# Altium schematic sheet Sheet1.SchDoc
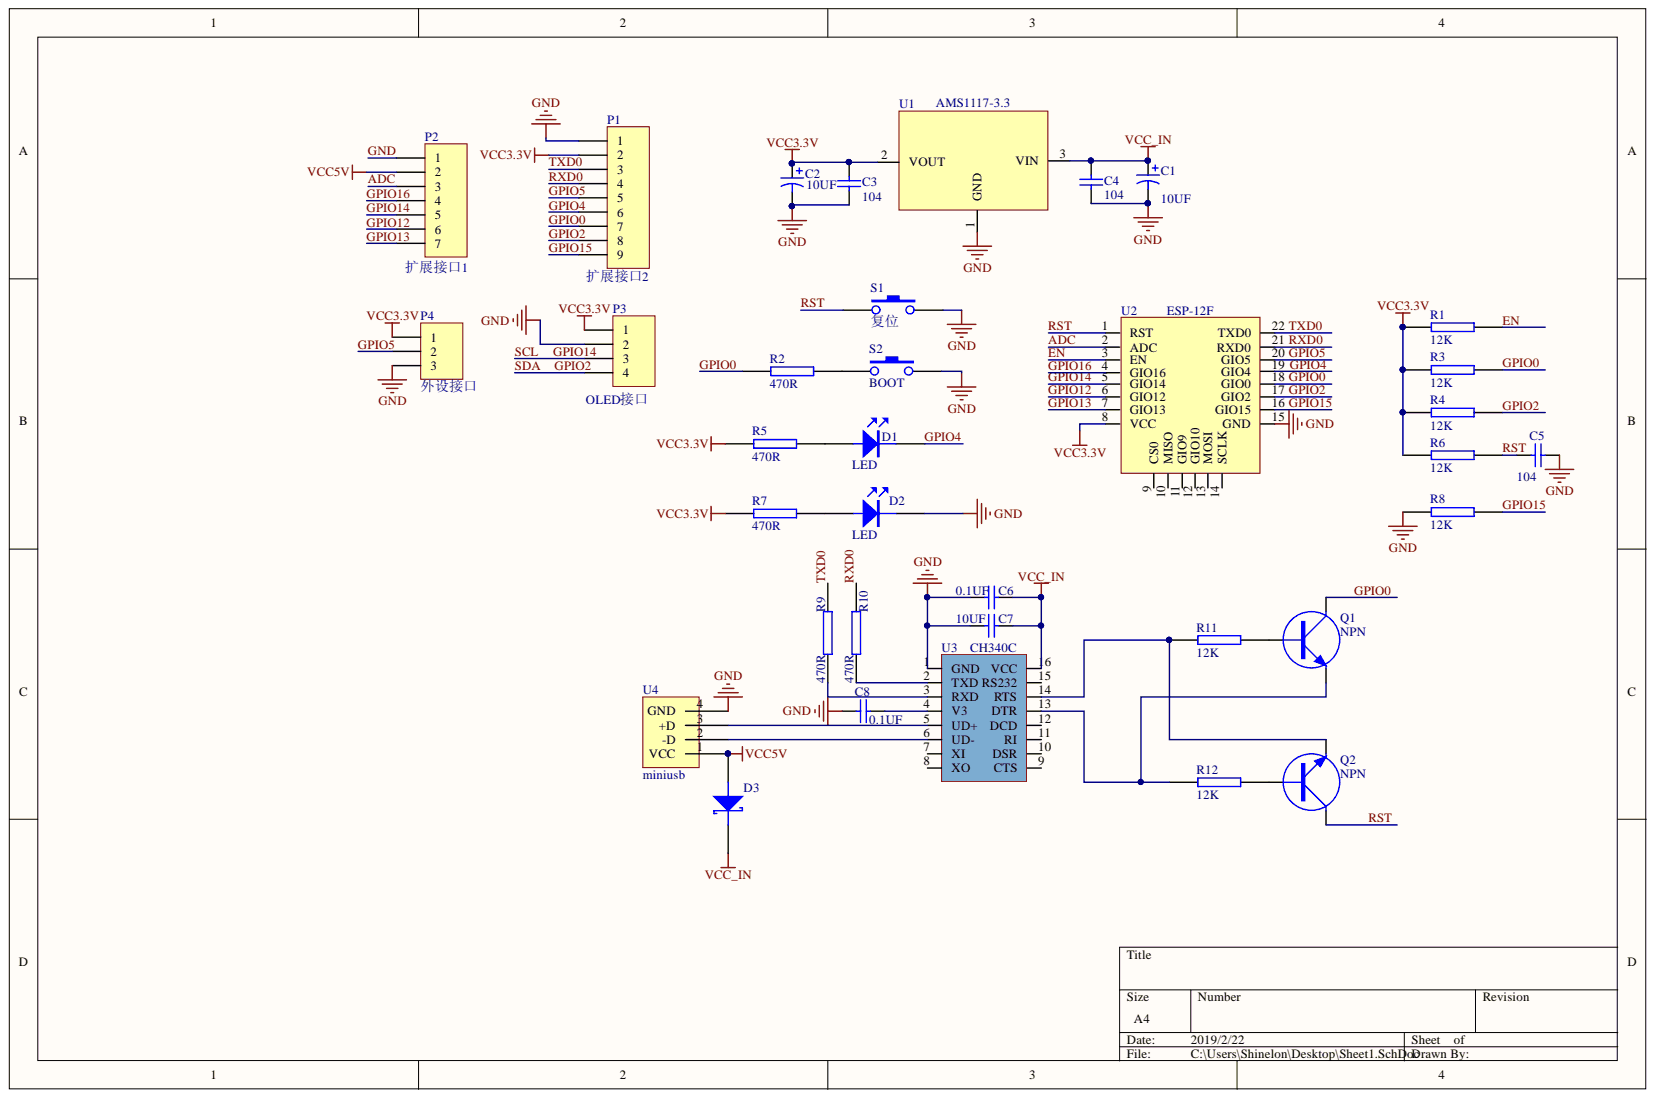
[diagram p0: Sheet1.SchDoc]

## Parts
C1  value 10UF  [3216C]
C2  value 10UF  [3216C]
C3  value 104  [0603C]  (hidden comment: Cap)
C4  value 104  [0603C]  (hidden comment: Cap)
C5  value 104  [0603C]  (hidden comment: Cap)
C6  value 0.1UF  [0603C]  (hidden comment: Cap)
C7  value 10UF  [0805C]  (hidden comment: Cap)
C8  value 0.1UF  [0603C]  (hidden comment: Cap)
D1  LED  [0603D]
D2  LED  [0603D]
D3  (no value)  [SOD523]
P1  扩展接口2  [HDR1X9]
P2  扩展接口1  [HDR1X7]
P3  OLED接口  [HDR1X4]
P4  外设接口  [HDR1X3]
Q1  NPN  [SOT23A]
Q2  NPN  [SOT23A]
R1  12K  [0603m]
R2  470R  [0603m]
R3  12K  [0603m]
R4  12K  [0603m]
R5  470R  [0603m]
R6  12K  [0603m]
R7  470R  [0603m]
R8  12K  [0603m]
R9  470R  [0603m]
R10  470R  [0603m]
R11  12K  [0603m]
R12  12K  [0603m]
S1  复位  [Button_SMD]
S2  BOOT  [Button_SMD]
U1  AMS1117-3.3  [SOT223]
U2  ESP-12F  [ESP-12F]
U3  CH340C  [SO-16_M]
U4  miniusb  [USB1]

## Nets
VCC3.3V: C2.1 C3.2 P1.2 P3.1 P4.1 R1.1 R3.1 R4.1 R5.2 R6.1 R7.2 U1.2 U2.8(VCC)
VCC5V: D3.1 P2.2 U4.1(VCC)
VCC_IN: C1.1 C4.1 C6.2 C7.2 D3.2 U1.3 U3.16(VCC)
ADC: P2.3 U2.2(ADC)
EN: R1.2 U2.3(EN)
GND: C1.2 C2.2 C3.1 C4.2 C5.1 C6.1 C7.1 C8.1 D2.K P1.1 P2.1 P3.2 P4.3 R8.1 S1.2 S2.2 U1.1 U2.15(GND) U3.1(GND) U4.4(GND)
GPIO0: P1.7 Q1.3 R2.2 R3.2 U2.18(GIO0)
GPIO2: P1.8 P3.4 R4.2 U2.17(GIO2)
GPIO4: D1.K P1.6 U2.19(GIO4)
GPIO5: P1.5 P4.2 U2.20(GIO5)
GPIO12: P2.6 U2.6(GIO12)
GPIO13: P2.7 U2.7(GIO13)
GPIO14: P2.5 P3.3 U2.5(GIO14)
GPIO15: P1.9 R8.2 U2.16(GIO15)
GPIO16: P2.4 U2.4(GIO16)
RST: C5.2 Q2.3 R6.2 S1.1 U2.1(RST)
RXD0: P1.4 R10.2 U2.21(RXD0)
TXD0: P1.3 R9.2 U2.22(TXD0)
NetC8_2: C8.2 U3.4(V3)
NetD1_A: D1.A R5.1
NetD2_A: D2.A R7.1
NetQ1_1: Q1.1 R12.1 U3.13(DTR)
NetQ1_2: Q1.2 R11.2
NetQ2_1: Q2.1 R11.1 U3.14(RTS)
NetQ2_2: Q2.2 R12.2
NetR2_1: R2.1 S2.1
NetR9_1: R9.1 U3.3(RXD)
NetR10_1: R10.1 U3.2(TXD)
NetU3_5: U3.5(UD+) U4.3(+D)
NetU3_6: U3.6(UD-) U4.2(-D)

Not connected: U2 9(CS0) 10(MISO) 11(GIO9) 12(GIO10) 13(MOSI) 14(SCLK); U3 7(XI) 8(XO) 9(CTS) 10(DSR) 11(RI) 12(DCD) 15(RS232)

## Library data of the parts
C1 C2 = Cap Pol1: Polarized Capacitor (Radial); Component Kind: Standard; LatestRevisionDate: 17-Jul-2002; LatestRevisionNote: Re-released for DXP Platform.; Library Name: Miscellaneous Devices.IntLib; PackageDescription: Polarized Capacitor (Radial); 2 Leads; PackageReference: RB7.6-15; Published: 8-Jun-2000; Signal Integrity: Cap Pol; Simulation: CAP; Publisher: Altium Limited
C3-C8 = Cap: Capacitor; Component Kind: Standard; LatestRevisionDate: 17-Jul-2002; LatestRevisionNote: Re-released for DXP Platform.; Library Name: Miscellaneous Devices.IntLib; PackageDescription: Radial Cap, Thru-Hole; 2 Leads; 0.3 in Pin Spacing; PackageReference: RAD-0.3; Published: 8-Jun-2000; Signal Integrity: Cap; Simulation: CAP; Publisher: Altium Limited
D3 = DIODE SCHOTTKY: Schottky Diode; Component Kind: Standard; Library Name: Miscellaneous Devices.SCHLIB
P1 = Header 9: Header, 9-Pin; Component Kind: Standard; LatestRevisionDate: 17-Jul-2002; LatestRevisionNote: Re-released for DXP Platform.; Library Name: Miscellaneous Connectors.IntLib; Signal Integrity: Connector; Publisher: Altium Limited
P2 = Header 7: Header, 7-Pin; Component Kind: Standard; LatestRevisionDate: 17-Jul-2002; LatestRevisionNote: Re-released for DXP Platform.; Library Name: Miscellaneous Connectors.IntLib; Signal Integrity: Connector; Publisher: Altium Limited
P3 = Header 4: Header, 4-Pin; Component Kind: Standard; LatestRevisionDate: 17-Jul-2002; LatestRevisionNote: Re-released for DXP Platform.; Library Name: Miscellaneous Connectors.IntLib; Signal Integrity: Connector; Publisher: Altium Limited
P4 = Header 3: Header, 3-Pin; Component Kind: Standard; LatestRevisionDate: 17-Jul-2002; LatestRevisionNote: Re-released for DXP Platform.; Library Name: Miscellaneous Connectors.IntLib; Signal Integrity: Connector; Publisher: Altium Limited
Q1 Q2 = NPN: NPN Transistor; Component Kind: Standard; Library Name: Miscellaneous Devices.SCHLIB
R1-R12 = RES2: Component Kind: Standard; Library Name: 常用1.SchLib
S1 S2 = SW-PB: Component Kind: Standard; Library Name: Miscellaneous Devices.SCHLIB
U3 = CH340: Component Kind: Standard; Library Name: TLC521（光耦）.SchLib
U4 = usb: Component Kind: Standard; Library Name: TLC521（光耦）.SchLib
D1 D2 = LED: Component Kind: Standard; Library Name: Miscellaneous Devices.SCHLIB
U1 = AMS1117-3.3: Component Kind: Standard; Library Name: GY32-B1.SchLib
U2 = ESP-12F: Component Kind: Standard; Library Name: TLC521（光耦）.SchLib
